# Altium schematic sheet POWER-SCH.SchDoc
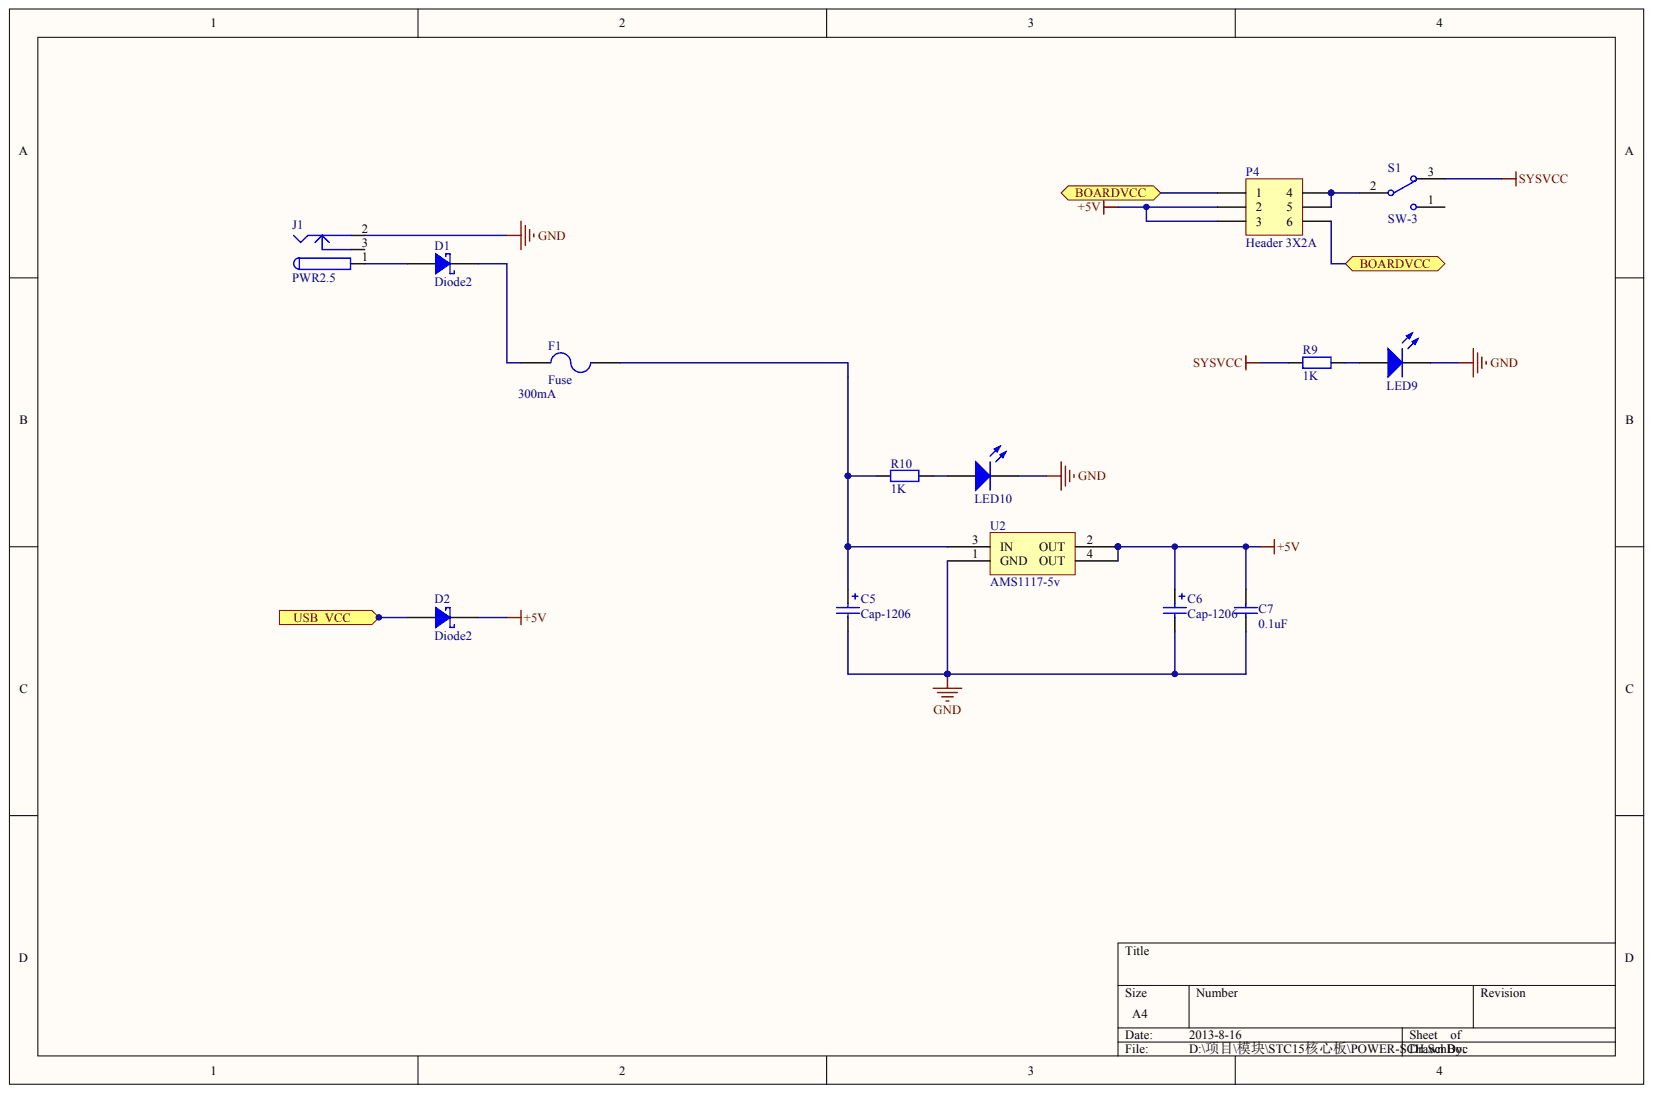
[diagram p0: POWER-SCH.SchDoc]

## Parts
C5  Cap-1206
C6  Cap-1206
C7  Cap 0805  (value not drawn)
D1  Diode2
D2  Diode2
F1  Fuse
J1  PWR2.5
LED9  LED_0805  (value not drawn)
LED10  LED_0805  (value not drawn)
P4  Header 3X2A
R9  RES  (value not drawn)
R10  RES  (value not drawn)
S1  SW-3
U2  AMS1117-5v

## Ports
BOARDVCC, USB_VCC

## Nets
+5V: C6.1 C7.2 D2.2 P4.2 P4.3 U2.2(OUT) U2.4(OUT)
GND: C5.2 C6.2 C7.1 J1.2 LED9.2 LED10.2 U2.1(GND)
SYSVCC: R9.2 S1.3
BOARDVCC: P4.1 P4.6 ; port BOARDVCC
USB_VCC: D2.1 ; port USB_VCC
NetC5_1: C5.1 F1.2 R10.2 U2.3(IN)
NetD1_1: D1.1 J1.1
NetD1_2: D1.2 F1.1
NetLED9_1: LED9.1 R9.1
NetLED10_1: LED10.1 R10.1
NetP4_4: P4.4 P4.5 S1.2

Not connected: J1 3; S1 1

## Library data of the parts
C5-C7 D1 D2 F1 J1 LED9 LED10 P4 R9 R10 S1 U2 = (no symbol name)
  C7: : 0.1uF
  D1 D2: : 0.5A
  F1: : 300mA
  P4: LatestRevisionDate: 17-Jul-2002; LatestRevisionNote: Re-released for DXP Platform.; Publisher: Altium Limited
  R9 R10: : 1K
